# Altium schematic sheet PI_Xbee_Mikro.SchDoc
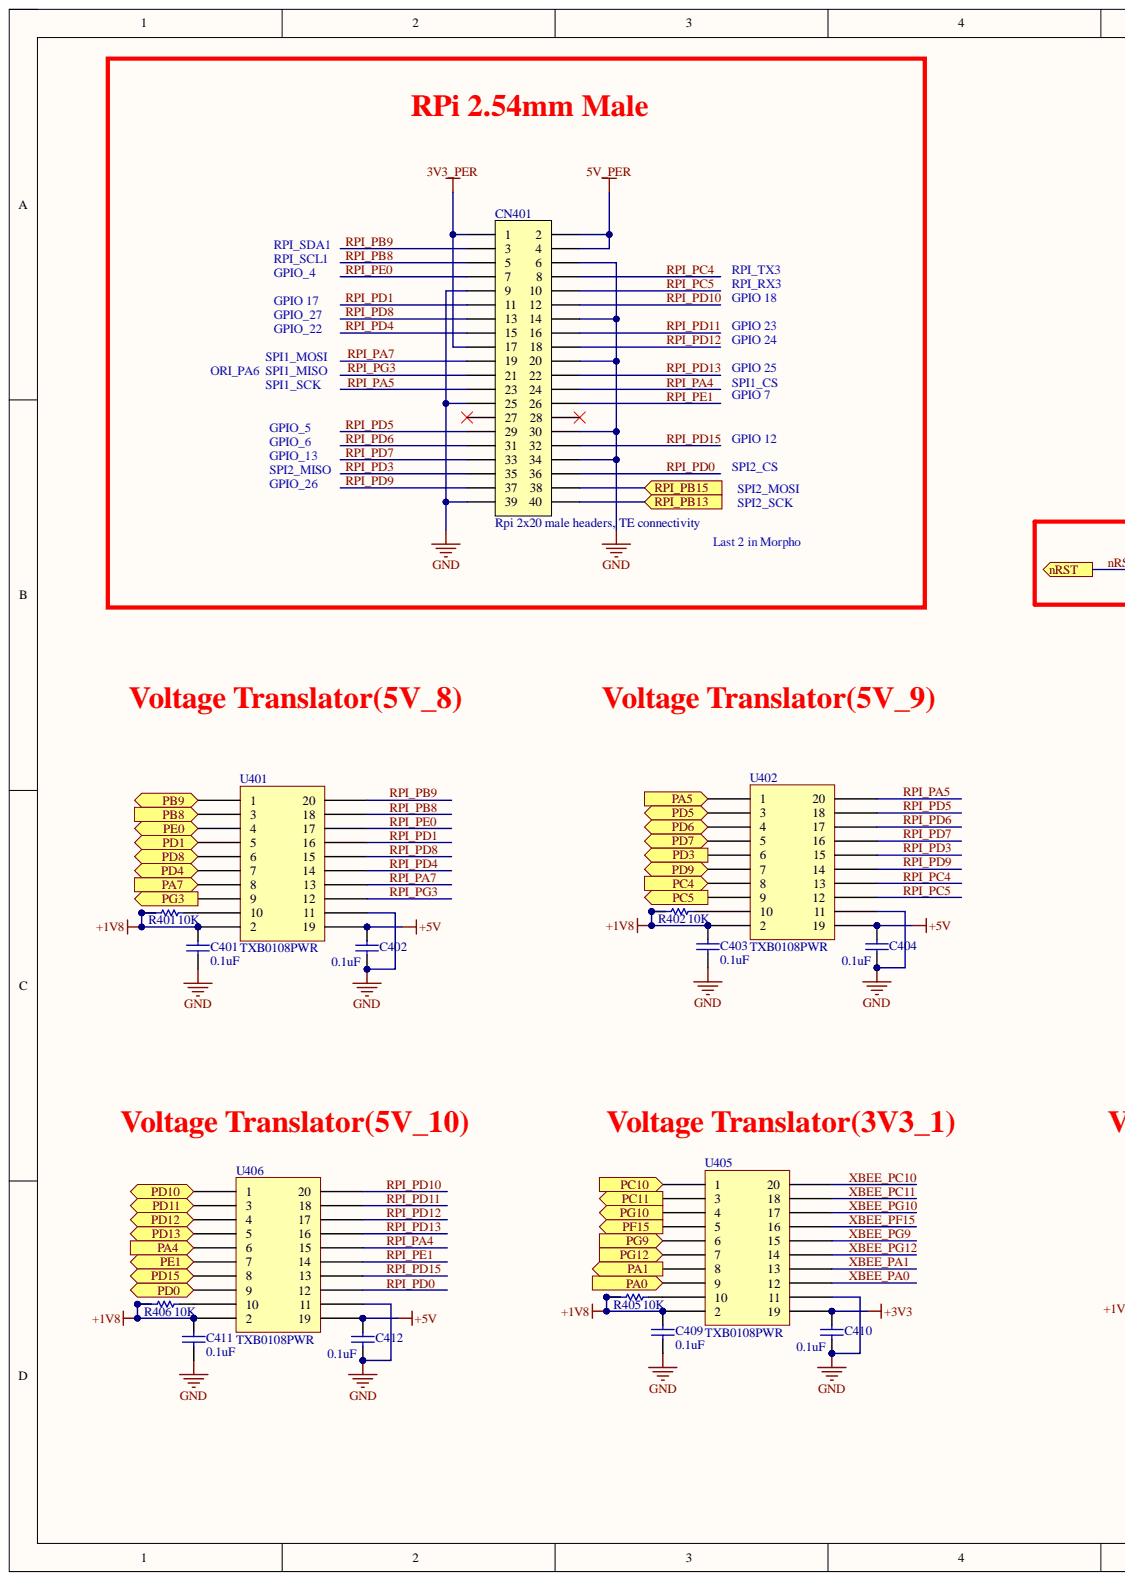
[diagram p0: PI_Xbee_Mikro.SchDoc, left]
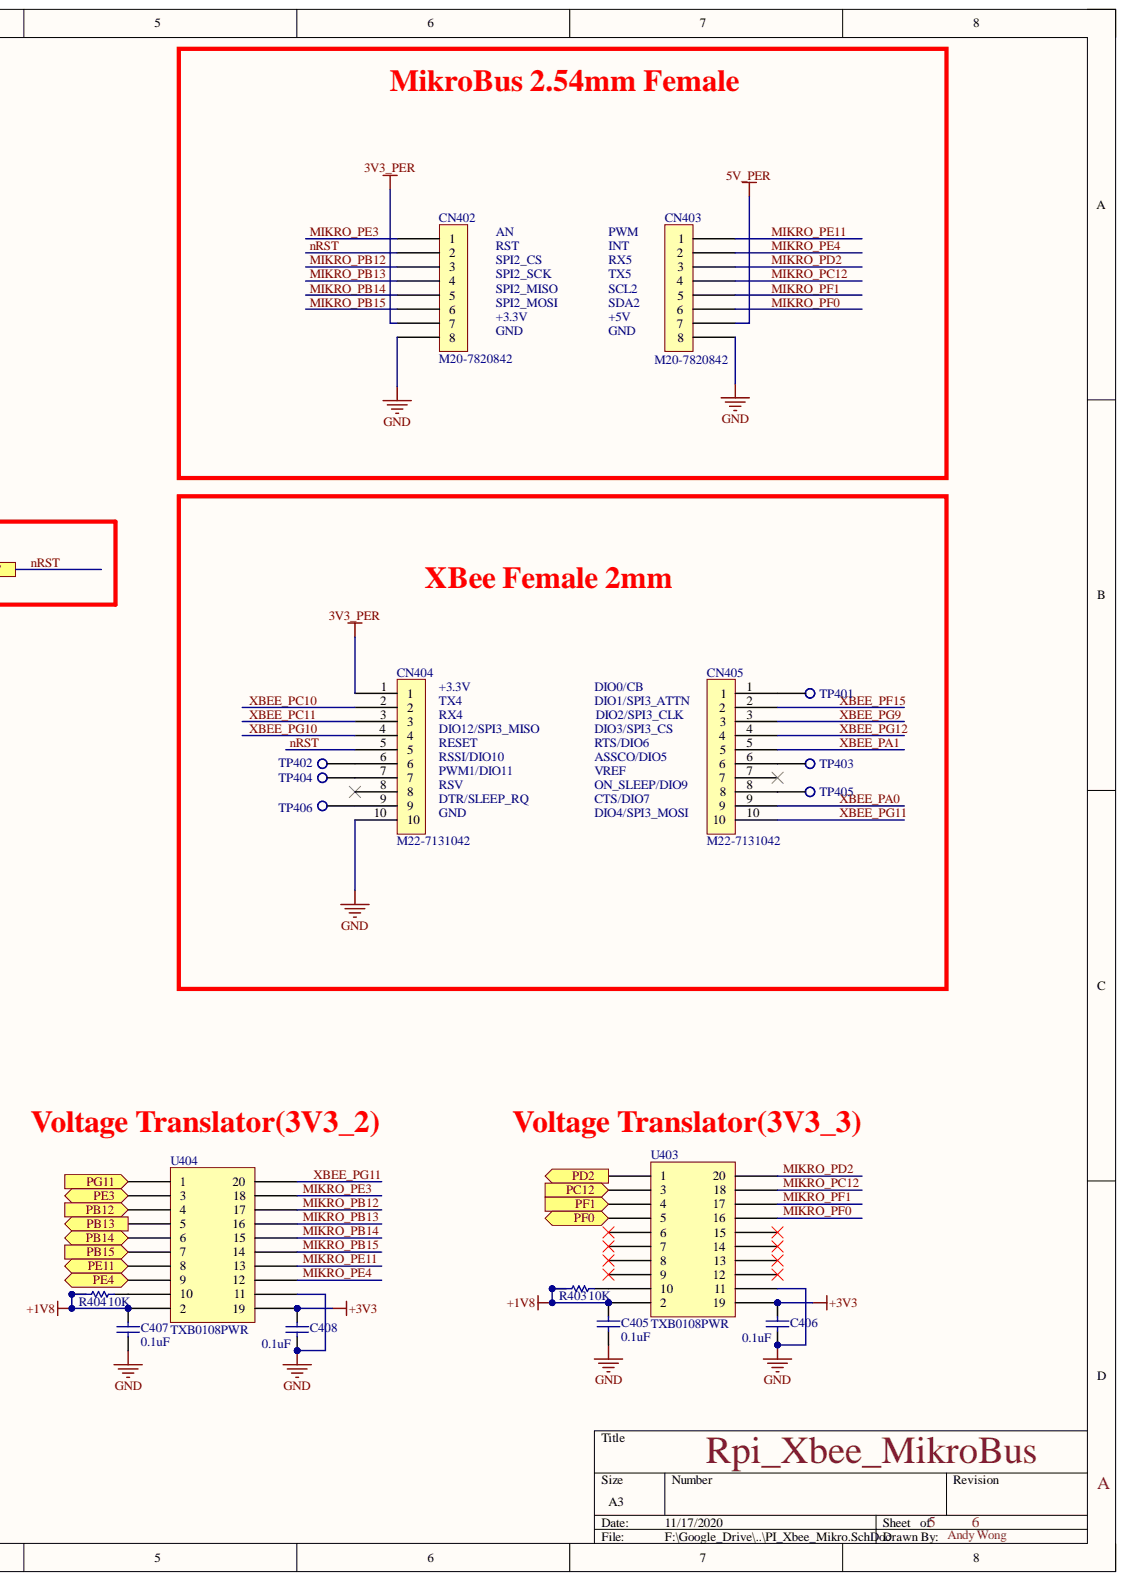
[diagram p1: PI_Xbee_Mikro.SchDoc, right]

## Parts
C401  0.1uF  [Cap 0402]
C402  0.1uF  [Cap 0402]
C403  0.1uF  [Cap 0402]
C404  0.1uF  [Cap 0402]
C405  0.1uF  [Cap 0402]
C406  0.1uF  [Cap 0402]
C407  0.1uF  [Cap 0402]
C408  0.1uF  [Cap 0402]
C409  0.1uF  [Cap 0402]
C410  0.1uF  [Cap 0402]
C411  0.1uF  [Cap 0402]
C412  0.1uF  [Cap 0402]
CN401  Rpi 2x20 male headers, TE connectivity  [2-146257-0]
CN402  M20-7820842  [M20-7820842]
CN403  M20-7820842  [M20-7820842]
CN404  M22-7131042  [M22-7131042]
CN405  M22-7131042  [M22-7131042]
R401  10K  [Res 0402]
R402  10K  [Res 0402]
R403  10K  [Res 0402]
R404  10K  [Res 0402]
R405  10K  [Res 0402]
R406  10K  [Res 0402]
TP401  Test Point  [TP]  (value not drawn)
TP402  Test Point  [TP]  (value not drawn)
TP403  Test Point  [TP]  (value not drawn)
TP404  Test Point  [TP]  (value not drawn)
TP405  Test Point  [TP]  (value not drawn)
TP406  Test Point  [TP]  (value not drawn)
U401  TXB0108PWR  [TXB0108PWR]
U402  TXB0108PWR  [TXB0108PWR]
U403  TXB0108PWR  [TXB0108PWR]
U404  TXB0108PWR  [TXB0108PWR]
U405  TXB0108PWR  [TXB0108PWR]
U406  TXB0108PWR  [TXB0108PWR]

## Ports
nRST, PA0, PA1, PA4, PA5, PA7, PB8, PB9, PB12, PB13, PB14, PB15, PC4, PC5, PC10, PC11, PC12, PD0, PD1, PD2, PD3, PD4, PD5, PD6, PD7, PD8, PD9, PD10, PD11, PD12, PD13, PD15, PE0, PE1, PE3, PE4, PE11, PF0, PF1, PF15, PG3, PG9, PG10, PG11, PG12, RPI_PB13, RPI_PB15

## Nets
3V3_PER: C406.2 C408.2 C410.2 CN401.1 CN401.17 CN402.7 CN404.1 U403.19 U404.19 U405.19
5V_PER: C402.2 C404.2 C412.2 CN401.2 CN401.4 CN403.7 U401.19 U402.19 U406.19
+1V8: C401.1 C403.1 C405.1 C407.1 C409.1 C411.1 R401.2 R402.2 R403.2 R404.2 R405.2 R406.2 U401.2 U402.2 U403.2 U404.2 U405.2 U406.2
GND: C401.2 C402.1 C403.2 C404.1 C405.2 C406.1 C407.2 C408.1 C409.2 C410.1 C411.2 C412.1 CN401.6 CN401.9 CN401.14 CN401.20 CN401.25 CN401.30 CN401.34 CN401.39 CN402.8 CN403.8 CN404.10 U401.11 U402.11 U403.11 U404.11 U405.11 U406.11
MIKRO_PB12: CN402.3 U404.17
MIKRO_PB13: CN402.4 U404.16
MIKRO_PB14: CN402.5 U404.15
MIKRO_PB15: CN402.6 U404.14
MIKRO_PC12: CN403.4 U403.18
MIKRO_PD2: CN403.3 U403.20
MIKRO_PE3: CN402.1 U404.18
MIKRO_PE4: CN403.2 U404.12
MIKRO_PE11: CN403.1 U404.13
MIKRO_PF0: CN403.6 U403.16
MIKRO_PF1: CN403.5 U403.17
nRST: CN402.2 CN404.5 ; port nRST
PA0: U405.9 ; port PA0
PA1: U405.8 ; port PA1
PA4: U406.6 ; port PA4
PA5: U402.1 ; port PA5
PA7: U401.8 ; port PA7
PB8: U401.3 ; port PB8
PB9: U401.1 ; port PB9
PB12: U404.4 ; port PB12
PB13: U404.5 ; port PB13
PB14: U404.6 ; port PB14
PB15: U404.7 ; port PB15
PC4: U402.8 ; port PC4
PC5: U402.9 ; port PC5
PC10: U405.1 ; port PC10
PC11: U405.3 ; port PC11
PC12: U403.3 ; port PC12
PD0: U406.9 ; port PD0
PD1: U401.5 ; port PD1
PD2: U403.1 ; port PD2
PD3: U402.6 ; port PD3
PD4: U401.7 ; port PD4
PD5: U402.3 ; port PD5
PD6: U402.4 ; port PD6
PD7: U402.5 ; port PD7
PD8: U401.6 ; port PD8
PD9: U402.7 ; port PD9
PD10: U406.1 ; port PD10
PD11: U406.3 ; port PD11
PD12: U406.4 ; port PD12
PD13: U406.5 ; port PD13
PD15: U406.8 ; port PD15
PE0: U401.4 ; port PE0
PE1: U406.7 ; port PE1
PE3: U404.3 ; port PE3
PE4: U404.9 ; port PE4
PE11: U404.8 ; port PE11
PF0: U403.5 ; port PF0
PF1: U403.4 ; port PF1
PF15: U405.5 ; port PF15
PG3: U401.9 ; port PG3
PG9: U405.6 ; port PG9
PG10: U405.4 ; port PG10
PG11: U404.1 ; port PG11
PG12: U405.7 ; port PG12
RPI_PA4: CN401.24 U406.15
RPI_PA5: CN401.23 U402.20
RPI_PA7: CN401.19 U401.13
RPI_PB8: CN401.5 U401.18
RPI_PB9: CN401.3 U401.20
RPI_PB13: CN401.40 ; port RPI_PB13
RPI_PB15: CN401.38 ; port RPI_PB15
RPI_PC4: CN401.8 U402.13
RPI_PC5: CN401.10 U402.12
RPI_PD0: CN401.36 U406.12
RPI_PD1: CN401.11 U401.16
RPI_PD3: CN401.35 U402.15
RPI_PD4: CN401.15 U401.14
RPI_PD5: CN401.29 U402.18
RPI_PD6: CN401.31 U402.17
RPI_PD7: CN401.33 U402.16
RPI_PD8: CN401.13 U401.15
RPI_PD9: CN401.37 U402.14
RPI_PD10: CN401.12 U406.20
RPI_PD11: CN401.16 U406.18
RPI_PD12: CN401.18 U406.17
RPI_PD13: CN401.22 U406.16
RPI_PD15: CN401.32 U406.13
RPI_PE0: CN401.7 U401.17
RPI_PE1: CN401.26 U406.14
RPI_PG3: CN401.21 U401.12
XBEE_PA0: CN405.9 U405.12
XBEE_PA1: CN405.5 U405.13
XBEE_PC10: CN404.2 U405.20
XBEE_PC11: CN404.3 U405.18
XBEE_PF15: CN405.2 U405.16
XBEE_PG9: CN405.3 U405.15
XBEE_PG10: CN404.4 U405.17
XBEE_PG11: CN405.10 U404.20
XBEE_PG12: CN405.4 U405.14
NetCN404_6: CN404.6 TP402.1
NetCN404_7: CN404.7 TP404.1
NetCN404_9: CN404.9 TP406.1
NetCN405_1: CN405.1 TP401.1
NetCN405_6: CN405.6 TP403.1
NetCN405_8: CN405.8 TP405.1
NetR401_1: R401.1 U401.10
NetR402_1: R402.1 U402.10
NetR403_1: R403.1 U403.10
NetR404_1: R404.1 U404.10
NetR405_1: R405.1 U405.10
NetR406_1: R406.1 U406.10

Not connected: CN401 27 28; CN404 8; CN405 7; U403 6 7 8 9 12 13 14 15

## Library data of the parts
C401-C412 = Cap: GRM155R71C104KA88, 0402; Component Kind: Standard; LatestRevisionDate: 17-Jul-2002; LatestRevisionNote: Re-released for DXP Platform.; Library Name: Miscellaneous Devices.IntLib; PackageDescription: Radial Cap, Thru-Hole; 2 Leads; 0.3 in Pin Spacing; PackageReference: RAD-0.3; Published: 8-Jun-2000; Signal Integrity: Cap; Simulation: CAP; Publisher: Altium Limited
CN401 = 2-146257-0: Component Kind: Standard; Description: Rpi 2x20 male headers, TE connectivity; Library Name: MA_Eva_Board.SchLib
CN402 CN403 = MikroBus: MikroBus Connector; Component Kind: Standard; Library Name: PCB_Connectors.SchLib
CN404 CN405 = XBee: XBEE HEADER SOCKET 2MM 10PIN; Component Kind: Standard; Library Name: PCB_Connectors.SchLib
R401-R406 = Res1: ERA-2AED103X, 0402; Component Kind: Standard; LatestRevisionDate: 17-Jul-2002; LatestRevisionNote: Re-released for DXP Platform.; Library Name: Miscellaneous Devices.IntLib; PackageDescription: Axial Device, Thru-Hole; 2 Leads; 0.3 in Pin Spacing; PackageReference: AXIAL-0.3; Published: 8-Jun-2000; Signal Integrity: Res; Simulation: RESISTOR; Publisher: Altium Limited
U401-U406 = TXB0108PWR: 8-Bit Bidirectional Voltage-Level Translator w; Component Kind: Standard; Library Name: MA_Eva_Board.SchLib
TP401-TP406 = Test Point: Component Kind: Standard; Description: Test Point; Library Name: MA_Eva_Board.SchLib
